# Altium schematic sheet constantCV.SchDoc
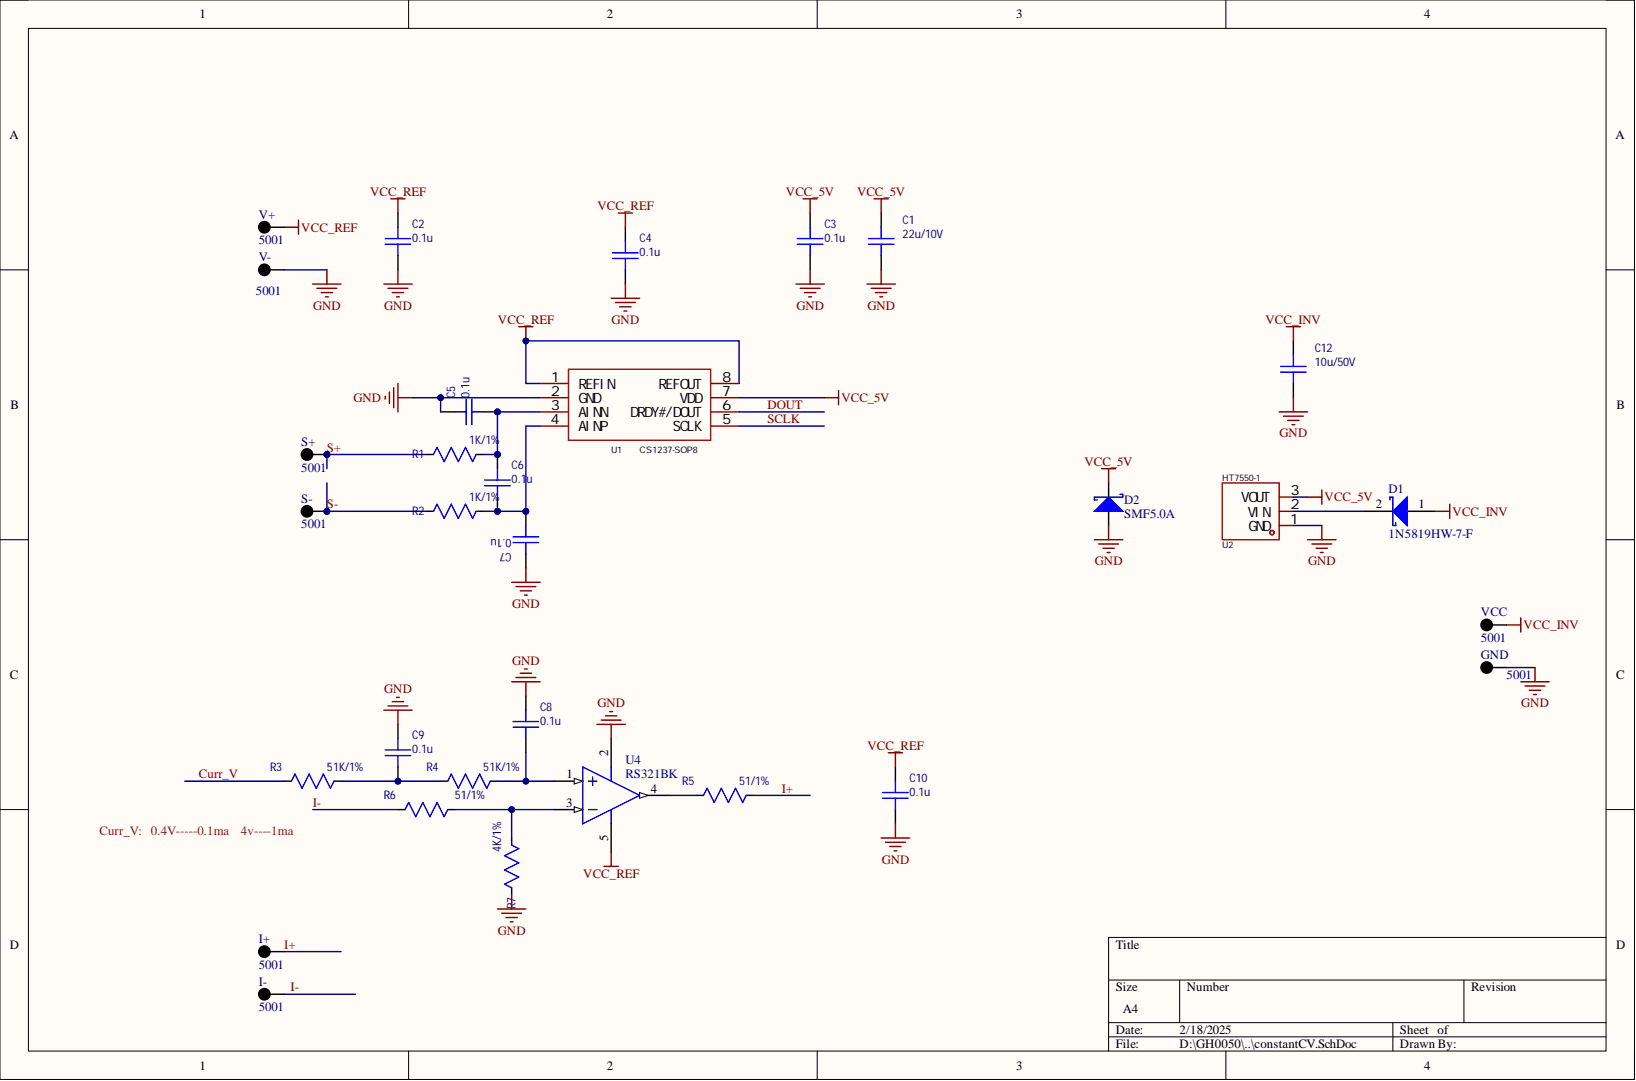
[diagram p0: constantCV.SchDoc]

## Parts
C1  value 22u/10V  [CAPC2012X127N_0805]  (hidden comment: Capacitor_0805)
C2  value 0.1u  [CAPC1608X092N_0603]  (hidden comment: Capacitor_0603)
C3  value 0.1u  [CAPC1608X092N_0603]  (hidden comment: Capacitor_0603)
C4  value 0.1u  [CAPC1608X092N_0603]  (hidden comment: Capacitor_0603)
C5  value 0.1u  [CAPC1608X092N_0603]  (hidden comment: Capacitor_0603)
C6  value 0.1u  [CAPC1608X092N_0603]  (hidden comment: Capacitor_0603)  (no BOM)
C7  value 0.1u  [CAPC1608X092N_0603]  (hidden comment: Capacitor_0603)
C8  value 0.1u  [CAPC1608X092N_0603]  (hidden comment: Capacitor_0603)
C9  value 0.1u  [CAPC1608X092N_0603]  (hidden comment: Capacitor_0603)
C10  value 0.1u  [CAPC1608X092N_0603]  (hidden comment: Capacitor_0603)
C12  value 10u/50V  [CAPC2012X127N_0805]  (hidden comment: Capacitor_0805)
D1  1N5819HW-7-F  [DIOD-SOD-123-2_V]
D2  SMF5.0A  [SOD123]
GND  5001  [KSTN-5001]  (no BOM)
I+  5001  [KSTN-5001]  (no BOM)
I-  5001  [KSTN-5001]  (no BOM)
R1  1K/1%  [RESC1608X055N_0603]
R2  1K/1%  [RESC1608X055N_0603]
R3  value 51K/1%  [RESC1608X055N_0603]  (hidden comment: 1K/1%)
R4  value 51K/1%  [RESC1608X055N_0603]  (hidden comment: 1K/1%)
R5  51/1%  [RESC1608X055N_0603]
R6  51/1%  [RESC1608X055N_0603]
R7  value 4K/1%  [RESC1608X055N_0603]  (hidden comment: 1K/1%)
S+  5001  [KSTN-5001]  (no BOM)
S-  5001  [KSTN-5001]  (no BOM)
U1  CS1237-SOP8  [SOIC-8_L5.0-W4.0-P1.27-LS6.0-BL]
U2  HT7550-1  [SOT-89_L4.5-W2.5-P1.50-LS4.2-BR]
U4  RS321BK  [SHBL-SOT-23-5_N]
V+  5001  [KSTN-5001]  (no BOM)
V-  5001  [KSTN-5001]  (no BOM)
VCC  5001  [KSTN-5001]  (no BOM)

## Nets
GND: C1.2 C2.2 C3.2 C4.2 C5.1 C7.1 C8.1 C9.1 C10.2 C12.2 D2.1 GND.1 R7.2 U1.2(GND) U2.1 U4.2 V-.1
VCC_5V: C1.1 C3.1 D2.2 U1.7(VDD) U2.3
VCC_INV: C12.1 D1.1 VCC.1
VCC_REF: C2.1 C4.1 C10.1 U1.1(REFIN) U1.8(REFOUT) U4.5 V+.1
Curr_V: R3.2
DOUT: U1.6(DRDY#/DOUT)
I+: I+.1 R5.1
I-: I-.1 R6.2
S+: R1.2 S+.1
S-: R2.2 S-.1
SCLK: U1.5(SCLK)
NetC5_2: C5.2 C6.1 R1.1 U1.3(AINN)
NetC6_2: C6.2 C7.2 R2.1 U1.4(AINP)
NetC8_2: C8.2 R4.1 U4.1
NetC9_2: C9.2 R3.1 R4.2
NetD1_2: D1.2 U2.2
NetR5_2: R5.2 U4.4
NetR6_1: R6.1 R7.1 U4.3

## Library data of the parts
C1 C12 = Capacitor_0805: Capacitor, MLCC; Manufacturer: KEMET; PartNumber: C0805C106K8PACTU; TempCo: [tempco]; Tolerance: [tol]; Voltage Rating: [voltage]; Component Kind: Standard; ComponentLink1Description: Datasheet; Package: 0805; SupplierDescription: CAP CER 10UF 10V X5R 0805; Library Name: Karl.SchLib
C2-C10 = Capacitor_0603: Capacitor, MLCC; Manufacturer: KEMET; PartNumber: C0603C104M5RACTU; TempCo: [tempco]; Tolerance: [tol]; Voltage Rating: [voltage]; ComponentLink1Description: Datasheet; Package: 0603; SupplierDescription: CAP CER 0.1UF 50V X7R 0603; Library Name: Karl.SchLib
  C2-C5 C7-C10: Component Kind: Standard
D1 = 1N5819HW-7-F: Maximum Reverse Current IR (uA): 50; Packing: Tape and Reel; Peak Forward Surge Current IFSM (A): 25; Peak Repetitive Reverse Voltage VRRM (V): 40; VR (RMS) (V): 28; Component Kind: Standard; Mounting Technology: Surface Mount; Operating and Storage Temperature Range: -65 to 125 degC; PackageDescription: 2-Pin SOD-123, Body 2.7 x 1.55 mm, Pitch 3.7 mm; PackageReference: SOD-123-2; PartNumber: 1N5819HW-7-F; RoHS: TRUE; Total Capacitance CT (pF): 50; Value: 1N5819HW-7-F; Library Name: Bogen.SchLib
D2 = DIODE S: Value: SMBJ6.5A; Component Kind: Standard
GND I+ I- S+ S- V+ V- VCC = 5001: Test Point, Black, 1-Pin THD, RoHS, Bulk; Manufacturer: Keystone; Value: 5001; Library Name: Bogen.SchLib
R1-R7 = Resistor_0603: Resistor, Standard; Manufacturer: Yageo; PartNumber: RC0603FR-1075KL; Power Rating: [power]; TempCo: [tempco]; Tolerance: tol; Component Kind: Standard; ComponentLink1Description: Datasheet; Package: 0603; SupplierDescription: RES SMD 75K OHM 1% 1/10W 0603; Library Name: Karl.SchLib
  R1 R2: Value: 1K/1%
  R5 R6: Value: 51/1%
U1 = CS1237-SOP8: Manufacturer: CHIPSEA(芯海科技); Add into BOM: yes; Component Kind: Standard; Convert to PCB: yes; Datasheet: https://atta.szlcsc.com/upload/public/pdf/source/20160706/1467801115662.pdf; Device: CS1237-SOP8; JLCPCB Part Class: Extended Part; LCSC Part Name: 1通道 24位ADC SPI接口; Manufacturer Part: CS1237-SOP8; PCB3D: SOIC-8_L4.9-W3.9-H1.7-LS6.0-P1.27; Supplier: LCSC; Supplier Footprint: SOP-8-150mil; Supplier Part: C77801; Symbol: CS1237-SO
U2 = HT7550-1_C347189: Manufacturer: UMW(友台半导体); Add into BOM: yes; Dropout Voltage: 100mV@(1mA); Maximum Input Voltage: 30V; Number of Outputs: 1; Output Current: 100mA; Output Polarity: 正极; Output Type: 固定; Output Voltage: 5V; standby current: 3uA; Component Kind: Standard; Convert to PCB: yes; Datasheet: https://atta.szlcsc.com/upload/public/pdf/source/20241210/442D8F583BE08BBCCA568BF38D964031.pdf; Device: HT7550-1_C347189; JLCPCB Part Class: Extended Part; LCSC Part Name: 输入30V 输出5V 100mA; Manufacturer Part: HT7550-1; Noise: -; Operating Temperature: -25℃~+70℃; PCB3D: SOT-89-3_L4.3-W2.5-H1.6-LS4.1-P1.50; Power Supply Rejection Ratio (PSRR): -; Supplier: LCSC; Supplier Footprint: SOT-89; Supplier Part: C347189; Symbol: HT7550-1_C347189
U4 = BL321LFR: 1 MHz Rail-to-Rail IO Operational Amplifier, Single Channel, -40 to 125 degC, 5-Pin SOT23, RoHS, Tape and Reel; Manufacturer: Shanghai Belling; Component Kind: Standard; Operating Temperature Range(C): -40 to 150; Package Description: 5-Pin Small Outline Transistor, Body 1.6 x 2.9 mm, Pitch 0.95 mm; Package Reference: SOT23-5; PartNumber: BL321LFR; Value: RS321BK; Library Name: Bogen.SchLib; Publisher: Bolgen Studio
